# Altium schematic sheet MOSFETs.SchDoc
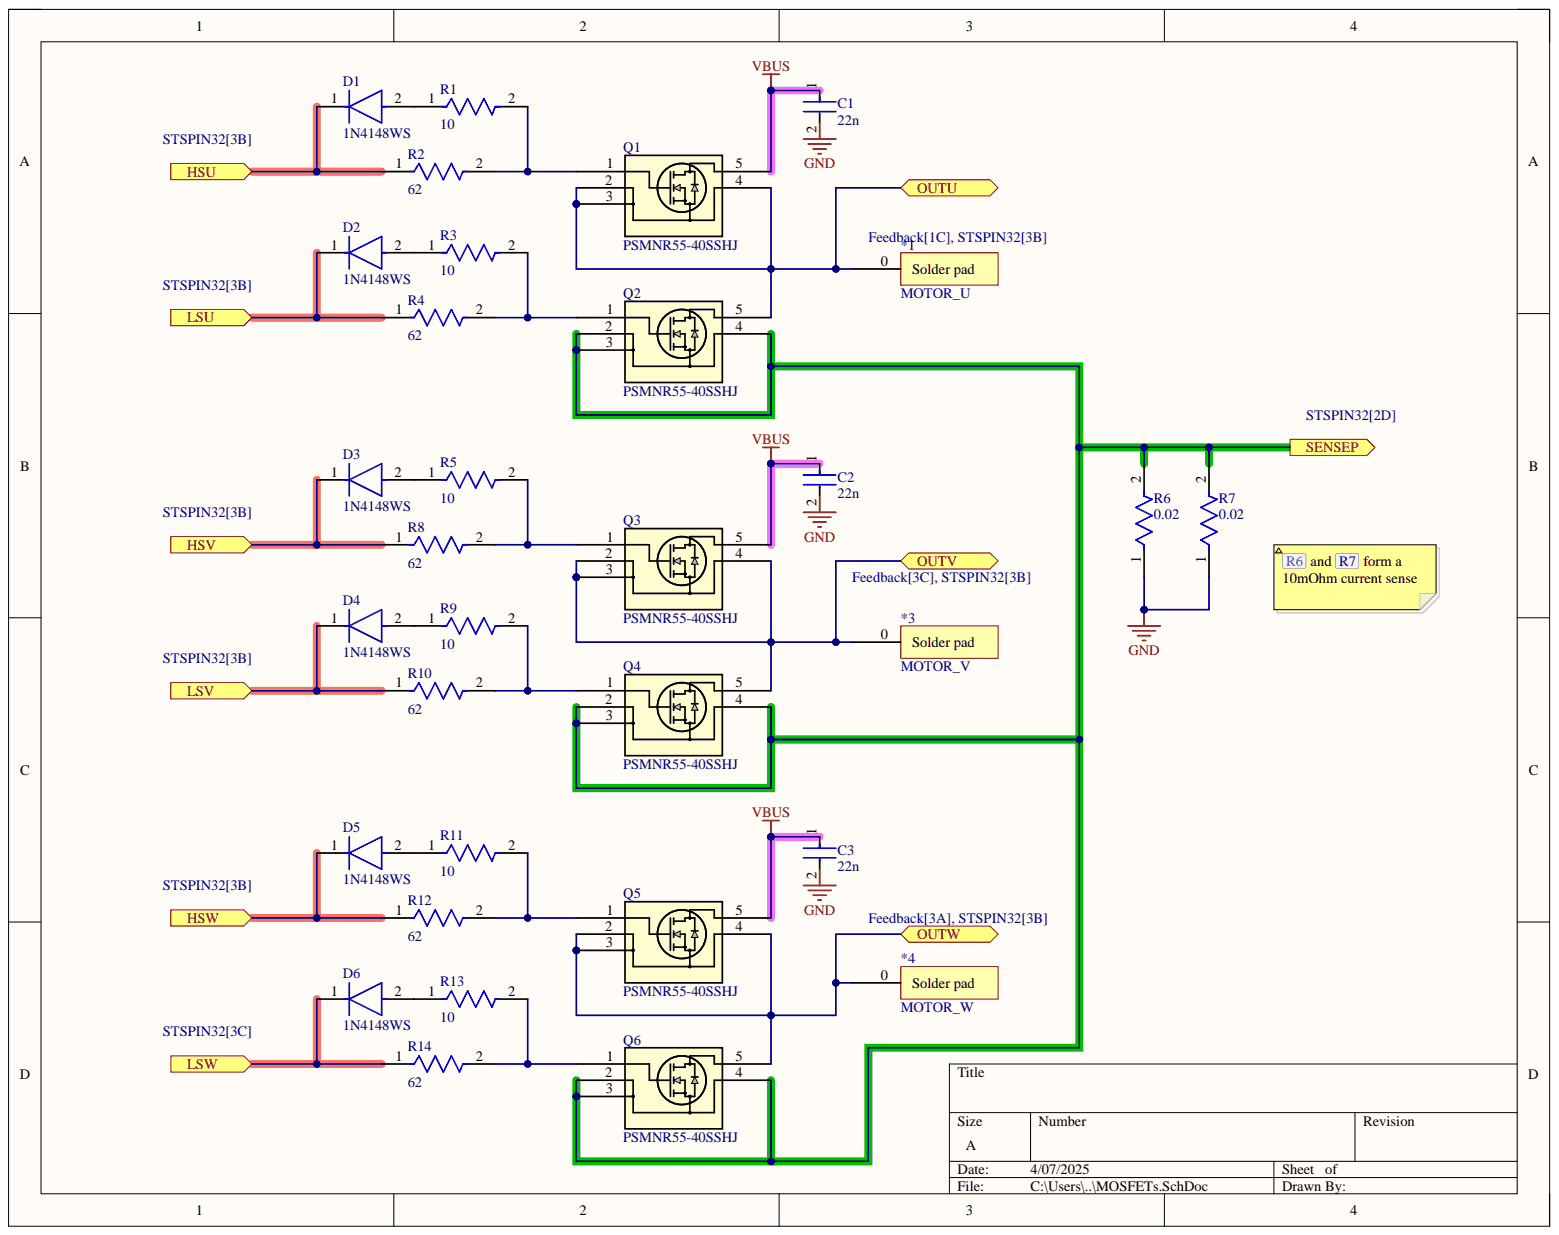
[diagram p0: MOSFETs.SchDoc]

## Parts
*1  MOTOR_U  [PAD_5x5]
*3  MOTOR_V  [PAD_5x5]
*4  MOTOR_W  [PAD_5x5]
C1  22n  [FP-CC0603-DA-MFG]
C2  22n  [FP-CC0603-DA-MFG]
C3  22n  [FP-CC0603-DA-MFG]
D1  1N4148WS  [FP-477AB-IPC_C]
D2  1N4148WS  [FP-477AB-IPC_C]
D3  1N4148WS  [FP-477AB-IPC_C]
D4  1N4148WS  [FP-477AB-IPC_C]
D5  1N4148WS  [FP-477AB-IPC_C]
D6  1N4148WS  [FP-477AB-IPC_C]
Q1  PSMNR55-40SSHJ  [LFPAK88_SOT1235_NEX]
Q2  PSMNR55-40SSHJ  [LFPAK88_SOT1235_NEX]
Q3  PSMNR55-40SSHJ  [LFPAK88_SOT1235_NEX]
Q4  PSMNR55-40SSHJ  [LFPAK88_SOT1235_NEX]
Q5  PSMNR55-40SSHJ  [LFPAK88_SOT1235_NEX]
Q6  PSMNR55-40SSHJ  [LFPAK88_SOT1235_NEX]
R1  10  [FP-CRCW0603-e3-IPC_A]
R2  62  [FP-CRCW0603-e3-IPC_A]
R3  10  [FP-CRCW0603-e3-IPC_A]
R4  62  [FP-CRCW0603-e3-IPC_A]
R5  10  [FP-CRCW0603-e3-IPC_A]
R6  0.02  [FP-RLP73V3AR020FTDF-MFG]
R7  0.02  [FP-RLP73V3AR020FTDF-MFG]
R8  62  [FP-CRCW0603-e3-IPC_A]
R9  10  [FP-CRCW0603-e3-IPC_A]
R10  62  [FP-CRCW0603-e3-IPC_A]
R11  10  [FP-CRCW0603-e3-IPC_A]
R12  62  [FP-CRCW0603-e3-IPC_A]
R13  10  [FP-CRCW0603-e3-IPC_A]
R14  62  [FP-CRCW0603-e3-IPC_A]

## Ports
HSU, HSV, HSW, LSU, LSV, LSW, OUTU, OUTV, OUTW, SENSEP

## Nets
GND: C1.2 C2.2 C3.2 R6.1 R7.1
VBUS: C1.1 C2.1 C3.1 Q1.5 Q3.5 Q5.5
HSU: D1.1 R2.1 ; port HSU
HSV: D3.1 R8.1 ; port HSV
HSW: D5.1 R12.1 ; port HSW
LSU: D2.1 R4.1 ; port LSU
LSV: D4.1 R10.1 ; port LSV
LSW: D6.1 R14.1 ; port LSW
OUTU: *1.0 Q1.2 Q1.3 Q1.4 Q2.5 ; port OUTU
OUTV: *3.0 Q3.2 Q3.3 Q3.4 Q4.5 ; port OUTV
OUTW: *4.0 Q5.2 Q5.3 Q5.4 Q6.5 ; port OUTW
SENSEP: Q2.2 Q2.3 Q2.4 Q4.2 Q4.3 Q4.4 Q6.2 Q6.3 Q6.4 R6.2 R7.2 ; port SENSEP
NetD1_2: D1.2 R1.1
NetD2_2: D2.2 R3.1
NetD3_2: D3.2 R5.1
NetD4_2: D4.2 R9.1
NetD5_2: D5.2 R11.1
NetD6_2: D6.2 R13.1
NetQ1_1: Q1.1 R1.2 R2.2
NetQ2_1: Q2.1 R3.2 R4.2
NetQ3_1: Q3.1 R5.2 R8.2
NetQ4_1: Q4.1 R9.2 R10.2
NetQ5_1: Q5.1 R11.2 R12.2
NetQ6_1: Q6.1 R13.2 R14.2

## Library data of the parts
*1 *3 *4 = Solder_PAD: Component Kind: Standard; Library Name: PSMNR55-40SSHJ.SchLib
C1-C3 = CMP-2100-03602-3: CAP CER 0.022UF 50V X7R 0603; Capacitance: 22nF; Dielectric Material: Ceramic; Insulation Resistance: 100GR; Tolerance: 10%; Case Code (Imperial): 0603; Case Code (Metric): 1608; Case/Package: 0603; Component Kind: Standard; Depth: 0.8mm; Dielectric: X7R; Halogen Free: Halogen Free; Height: 0.8mm; Lead Free: Lead Free; Length: 1.6mm; Library Name: Altium Content Vault; Max Operating Temperature: 125°C; Min Operating Temperature: -55°C; Mount: Surface Mount; Number of Pins: 2; Package Quantity: 1; Packaging: Tape and Reel; Radiation Hardening: No; REACH SVHC: No SVHC; Resistor Type: Ceramic; RoHS Compliant: Yes; Series: CC; Termination: SMD/SMT; Thickness: 0.035inch; Voltage: 50V; Voltage Rating: 50V; Voltage Rating (DC): 50V; Width: 0.031inch
D1-D6 = CMP-07163-000040-1: DIODE GEN PURP 75V 150MA SOD323F; Element Configuration: Single; Forward Voltage: 1V; Max Forward Surge Current (Ifsm): 2A; Peak Reverse Current: 5uA; Power Dissipation: 200mW; Recovery Time: 4ns; Average Rectified Current: 150mA; Component Kind: Standard; Contact Plating: Tin; Forward Current: 150mA; Height: 1mm; Lead Free: Lead Free; Length: 1.8mm; Library Name: Altium Content Vault; Max Operating Temperature: 150°C; Max Repetitive Reverse Voltage (Vrrm): 75V; Max Reverse Voltage (DC): 75V; Min Operating Temperature: -55°C; Mount: Surface Mount; Number of Pins: 2; Package Quantity: 1; Packaging: Tape and Reel; Radiation Hardening: No; REACH SVHC: No SVHC; Reverse Recovery Time: 4ns; Reverse Voltage: 75V; Weight: 0.0276g; Width: 1.35mm
Q1-Q6 = PSMNR55-40SSHJ: No Description Available; Mfr_Name: Nexperia; Component Kind: Standard; Library Name: PSMNR55-40SSHJ.SchLib; RefDes: RefDes; Type: Type
R1-R5 R8-R14 = CMP-2000-03845-2: RES Thick Film, 10Ω, 1%, 0.1W, 100ppm/°C, 0603; Max Power Dissipation: 100mW; Resistance: 10R; Voltage Rating: 75V; Case Code (Imperial): 0603; Case Code (Metric): 1608; Case/Package: 0603; Component Kind: Standard; Composition: Thick Film; Contact Plating: Tin; Depth: 0.85mm; Features: Automotive AEC-Q200; Height: 0.45mm; Lead Free: Lead Free; Length: 1.55mm; Library Name: Altium Content Vault; Max Operating Temperature: 155°C; Military Standard: Not; Min Operating Temperature: -55°C; Mount: Surface Mount; Number of Pins: 2; Number of Terminations: 2; Package Quantity: 10; Packaging: Tape and Reel; Power Rating: 100mW; Temperature Coefficient: 100ppm/°C; Termination: SMD/SMT; Tolerance: 1%; Voltage Rating (DC): 75V; Weight: 7.1E-05oz; Width: 0.033inch
R6 R7 = CMP-03211-011419-1: RES 0.02 OHM 1% 2W 2512; Number of Resistors: 1; Resistance: 20mR; Temperature Coefficient: 600ppm/°C; Component Kind: Standard; ELV: Compliant; Library Name: Altium Content Vault; Mount: Surface Mount; Number of Terminations: 2; Packaging: Tape and Reel; Power Rating: 2W; Resistor Type: Thick Film; RoHS Compliant: Yes; Termination: Solder; Tolerance: 1%
